# Altium schematic sheet ATV4K-dfu-board.SchDoc(ATV4K-dfu-board)
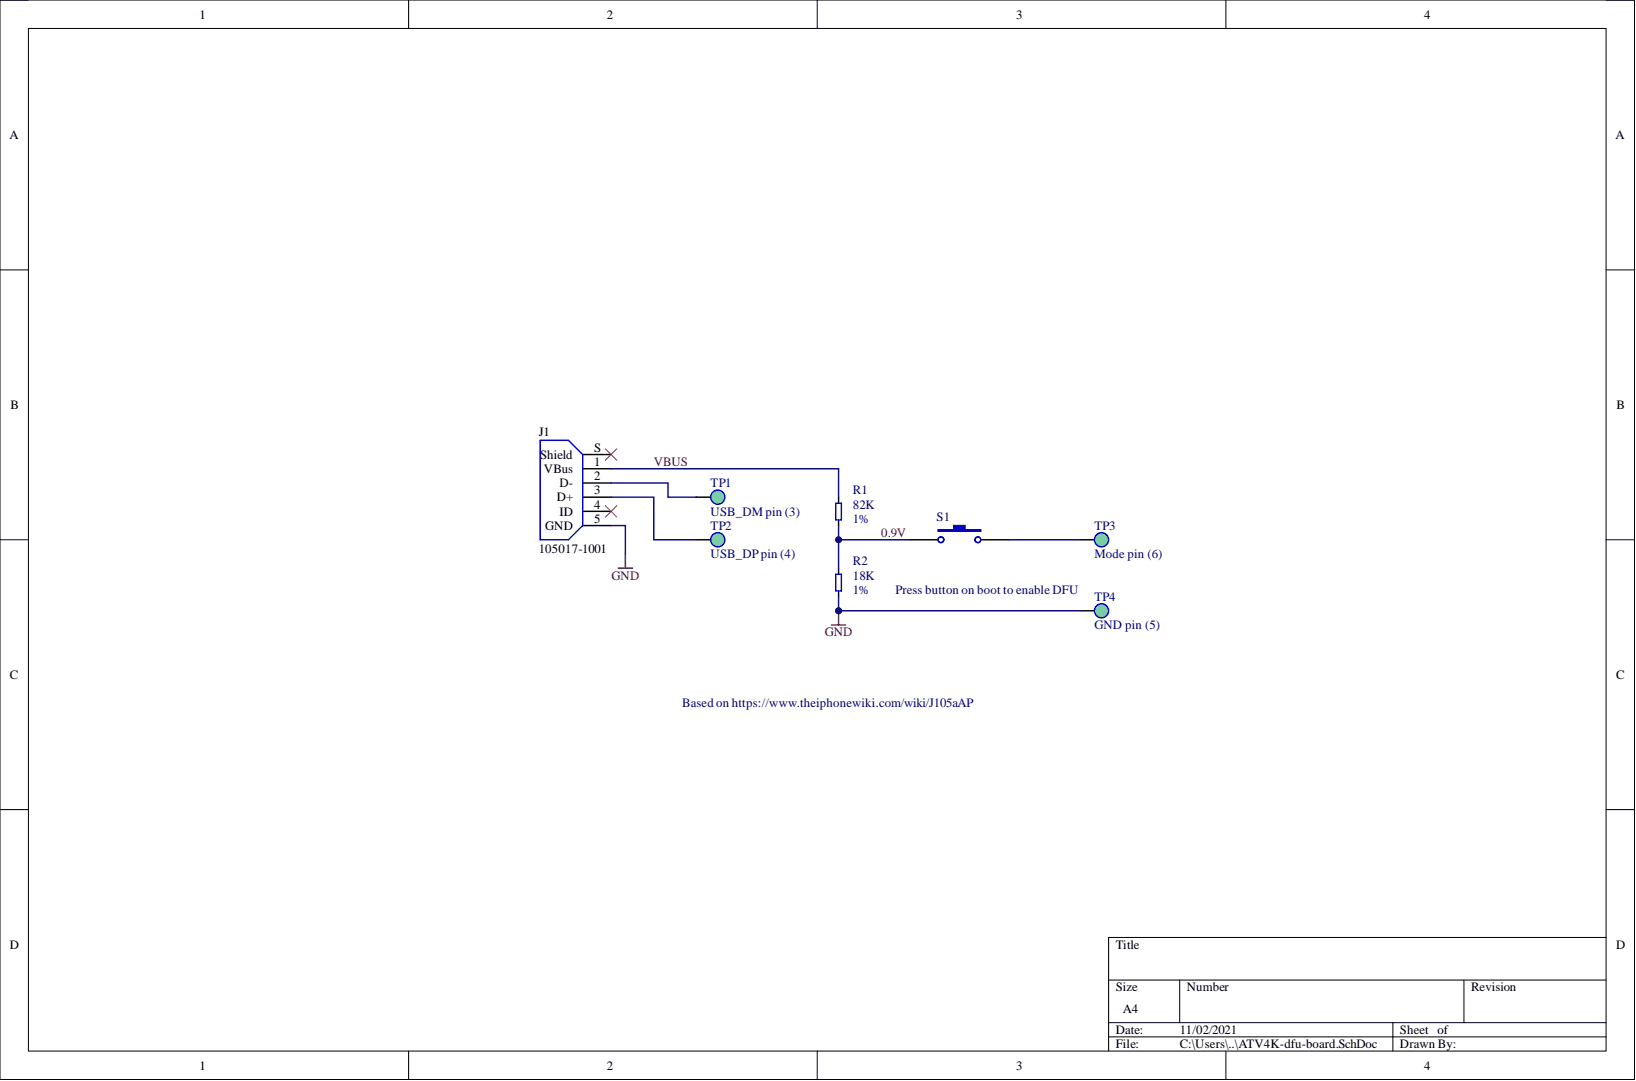
[diagram p0: ATV4K-dfu-board.SchDoc]

## Parts
J1  105017-1001  [105017-1001-Footprint-1]
R1  82K  Tolerance: 1%  [RESC1608X06]
R2  18K  Tolerance: 1%  [RESC1608X06]
S1  (no value)  [1437566-4-Footprint-1]
TP1  USB_DM pin (3)  [Test point 0.5mm 0.75mm]
TP2  USB_DP pin (4)  [Test point 0.5mm 0.75mm]
TP3  Mode pin (6)  [Test point 0.5mm 0.75mm]
TP4  GND pin (5)  [Test point 0.5mm 0.75mm]

## Nets
GND: J1.5(GND) R2.1 TP4.1
0.9V: R1.1 R2.2 S1.1
VBUS: J1.1(VBus) R1.2
NetJ1_2: J1.2(D-) TP1.1
NetJ1_3: J1.3(D+) TP2.1
NetS1_4: S1.4 TP3.1

Not connected: J1 4(ID) S(Shield)

## Library data of the parts
J1 = CMP-001-0836-1: MOLEX         105017-1001             USB Connector, Micro USB Type B, USB 2.0, Receptacle, 5 Ways, Surface Mount, Right Angle                          New; Max Voltage Rating (AC): 30V; Number of Contacts: 5; Number of Ports: 1; Pitch: 0.65mm; USB Standard: USB 2.0; Component Kind: Standard; Connector Type: USB; Contact Material: Copper Alloy; Contact Plating: Gold; Depth: 5mm; Gender: Receptacle; Housing Material: Polymer; Length: 7.5mm; Max Operating Temperature: 85°C; Min Operating Temperature: -30°C; Mount: Surface Mount,Horizontal,Through Hole; Number of Terminals/Pins: 5; Orientation: Right Angle; Package Quantity: 1; Packaging: Tape and Reel; Radiation Hardening: No; REACH SVHC: No SVHC; Series: 105017; Termination: Solder; Library Name: HEPIA Vault
R1 = CMP-001-0893-1: 82K 0603/1608; Code Case (Metric): 1608; Case Code (Imperial): 0603; Component Kind: Standard; Value: 82K; Library Name: HEPIA Vault
R2 = CMP-001-0371-6: 18K 0603/1608; Case Code (Imperial): 0603; Case Code (Metric): 1608; Component Kind: Standard; Value: 18K; Library Name: HEPIA Vault
S1 = CMP-001-0835-2: Switch Tactile OFF (ON) SPST Rectangular Button Gull Wing 0.05A 12VDC SMD Loose Piece; Actuator Length: 1.07mm; Actuator Material: Polyamide; Actuator Type: Standard; Contact Current Rating: 50mA; Contact Resistance: 100mR; Electrical Life: 20000Cycles; Illumination Type: Non-Illuminated,Illuminated; Max Voltage Rating (DC): 12V; Operating Force: 180gf; Switch Function: Off-Mom; Switch Travel: 0.25mm; Voltage Rating (DC): 24V; Case/Package: SMD/SMT; Component Kind: Standard; Contact Material: Brass; Contact Plating: Silver; Current Rating: 50mA; ELV: Compliant; Lead Free: Lead Free; Max Current Rating: 50mA; Max Operating Temperature: 85°C; Mechanical Life: 20000Cycles; Min Operating Temperature: -35°C; Mount: Surface Mount; Orientation: Straight; Package Quantity: 1800; Packaging: Tape and Reel; Radiation Hardening: No; REACH SVHC: Unknown; RoHS Compliant: Yes; Series: FSMSM; Termination: Gull Wing; Throw Configuration: SPST; Type: Switch; Library Name: HEPIA Vault
TP1-TP4 = CMP-001-0516-A.2: 0.75mm; Component Kind: Standard; Library Name: HEPIA Vault
